# Altium schematic sheet NU.N8051.EK05.001.SCHDOC
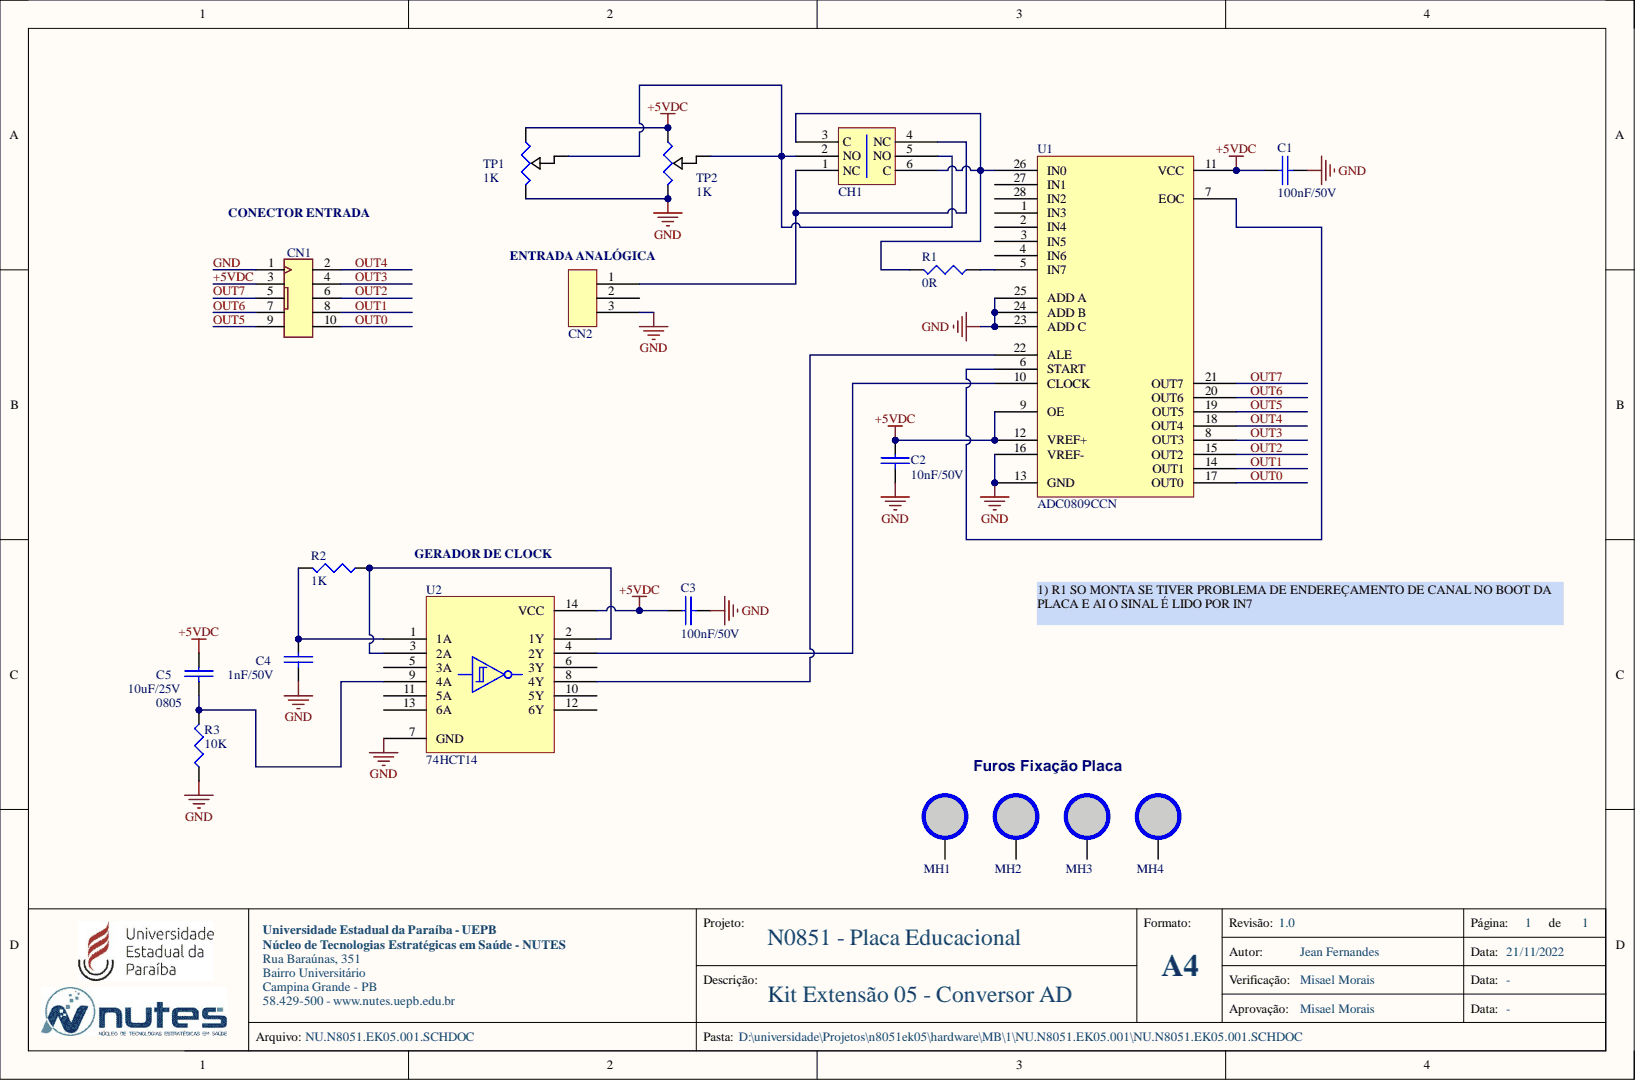
[diagram p0: NU.N8051.EK05.001.SCHDOC]

## Parts
C1  value 100nF/50V  [CAP-0603-IPC-MD]  (hidden comment: CAPACITOR)
C2  value 10nF/50V  [CAP-0603-IPC-MD]  (hidden comment: CAPACITOR)
C3  value 100nF/50V  [CAP-0603-IPC-MD]  (hidden comment: CAPACITOR)
C4  value 1nF/50V  [CAP-0603-IPC-MD]  (hidden comment: CAPACITOR)
C5  value 10uF/25V  Package: 0805  [CAP-0805-IPC-MD]  (hidden comment: CAPACITOR)
CH1  PSW-8-R  [PSW-8-R]  (value not drawn)
CN1  IDCEC-10-LP  [IDCEC-10-LP]  (value not drawn)
CN2  CON3  [KF142R-3P]  (value not drawn)
MH1  MH6MM4MM  [MOUNTING_HOLE]  (value not drawn)  (no BOM)
MH2  MH6MM4MM  [MOUNTING_HOLE]  (value not drawn)  (no BOM)
MH3  MH6MM4MM  [MOUNTING_HOLE]  (value not drawn)  (no BOM)
MH4  MH6MM4MM  [MOUNTING_HOLE]  (value not drawn)  (no BOM)
R1  value 0R  [RES-0603-IPC-MD]  (hidden comment: RESISTOR)
R2  value 1K  [RES-0603-IPC-MD]  (hidden comment: RESISTOR)
R3  value 10K  [RES-0603-IPC-MD]  (hidden comment: RESISTOR)
TP1  value 1K  [3590S-TRIMMER - SHORT]  (hidden comment: TRIMPOT)
TP2  value 1K  [3386F-TRIMMER]  (hidden comment: TRIMPOT)
U1  ADC0809CCN  [DIP28]
U2  value 74HCT14  [SOIC127P600X175-14M]  (hidden comment: 74XXX14)

## Nets
+5VDC: C1.1 C2.1 C3.1 C5.1 CN1.3 TP1.1 TP2.1 U1.9(OE) U1.11(VCC) U1.12(VREF+) U2.14(VCC)
GND: C1.2 C2.2 C3.2 C4.2 CN1.1 CN2.3 R3.1 TP1.3 TP2.3 U1.13(GND) U1.16(VREF-) U1.23(ADD_C) U1.24(ADD_B) U1.25(ADD_A) U2.7(GND)
OUT0: CN1.10 U1.17(OUT0)
OUT1: CN1.8 U1.14(OUT1)
OUT2: CN1.6 U1.15(OUT2)
OUT3: CN1.4 U1.8(OUT3)
OUT4: CN1.2 U1.18(OUT4)
OUT5: CN1.9 U1.19(OUT5)
OUT6: CN1.7 U1.20(OUT6)
OUT7: CN1.5 U1.21(OUT7)
NetC4_1: C4.1 R2.2 U2.1(1A)
NetC5_2: C5.2 R3.2 U2.9(4A)
NetCH1_1: CH1.1(NC) CH1.4(NC) CN2.1
NetCH1_2: CH1.2(NO) CH1.5(NO) TP1.2 TP2.2
NetCH1_3: CH1.3(C) CH1.6(C) R1.2 U1.26(IN0)
NetR1_1: R1.1 U1.5(IN7)
NetR2_1: R2.1 U2.2(1Y) U2.3(2A)
NetU1_6: U1.6(START) U1.7(EOC)
NetU1_10: U1.10(CLOCK) U2.4(2Y)
NetU1_22: U1.22(ALE) U2.8(4Y)

Not connected: CN2 2; MH1 1; MH2 1; MH3 1; MH4 1; U1 1(IN3) 2(IN4) 3(IN5) 4(IN6) 27(IN1) 28(IN2); U2 5(3A) 6(3Y) 10(5Y) 11(5A) 12(6Y) 13(6A)

## Library data of the parts
C1-C5 = CAPACITOR: General Purpose Capacitor; Manufacturer: Murata Electronics; Manufacturer Part Number: GRM188R71H104KA93D; Component Kind: Standard; Datasheet: https://datasheet.lcsc.com/lcsc/1810311126_Murata-Electronics-GRM188R71H104KA93D_C77055.pdf; Price: 0.0049; Supplier: JLCPCB; Supplier Link: https://jlcpcb.com/; Supplier Part Number: C77055; Library Name: NUTES_GENERAL.SCHLIB
  C1-C4: Package: 0603
CH1 = PSW-8-R: Tactile Switch - 8mm x 8mm; Component Kind: Standard; Library Name: NUTES_GENERAL.SCHLIB
CN1 = IDCEC-10-LP: 2.54mm Straight 5 Push - Pull 2.54mm 2 Plugin,P=2.54mm IDC Connectors ROHS; Manufacturer: BOOMELE(Boom Precision Elec); Manufacturer Part Number: C5665; Value: C5665; Component Kind: Standard; Datasheet: https://datasheet.lcsc.com/lcsc/1810281612_BOOMELE-Boom-Precision-Elec-C5665_C5665.pdf; Package: IDC-TH_10P-P2.54_C5665; Price: 0.0731; Signal Integrity: Guessed Model; Supplier: JLCPCB; Supplier Link: https://jlcpcb.com/; Supplier Part Number: C5665; Library Name: NUTES_GENERAL.SCHLIB
CN2 = CON3: Connector 3 Pins; Component Kind: Standard; Library Name: NUTES_GENERAL.SCHLIB
MH1-MH4 = MH6MM4MM: Mounting Hole 6mm x 4 mm; Library Name: NUTES_GENERAL.SCHLIB
R1-R3 = RESISTOR: General Purpose Resistor; Manufacturer: Uni-royal; Manufacturer Part Number: 0603WAF1001T5E; Component Kind: Standard; Datasheet: https://datasheet.lcsc.com/lcsc/2206010130_UNI-ROYAL-Uniroyal-Elec-0603WAF1001T5E_C21190.pdf; Package: 0603; Price: 0.001; Supplier: JLCPCB; Supplier Link: https://jlcpcb.com/; Supplier Part Number: C21190; Library Name: NUTES_GENERAL.SCHLIB
TP1 TP2 = TRIMPOT: General Purpose Trimpot; Component Kind: Standard; Library Name: NUTES_GENERAL.SCHLIB
U1 = ADC0809CCN: Analog to Digital Converters - ADC; Manufacturer: Texas; Component Kind: Standard; Datasheet: https://www.ti.com/lit/ds/symlink/adc0809-n.pdf?HQS=dis-mous-null-mousermode-dsf-pf-null-wwe&ts=1668936742271&ref_url=https%253A%252F%252Fbr.mouser.com%252F; Manufacturer Part Number: ADC0809CCN; Package: DIP-28; Supplier: Mouser; Supplier Part Number: 926-ADC0809CCN; Value: ADC0809CCN; Library Name: NUTES_GENERAL.SCHLIB
U2 = 74XXX14: Inverters Hex Schmitt-Trigger; Component Kind: Standard; Library Name: NUTES_GENERAL.SCHLIB
